# Altium schematic sheet 遥控器_V1_0_D.SchDoc(遥控器_V1_0_D)
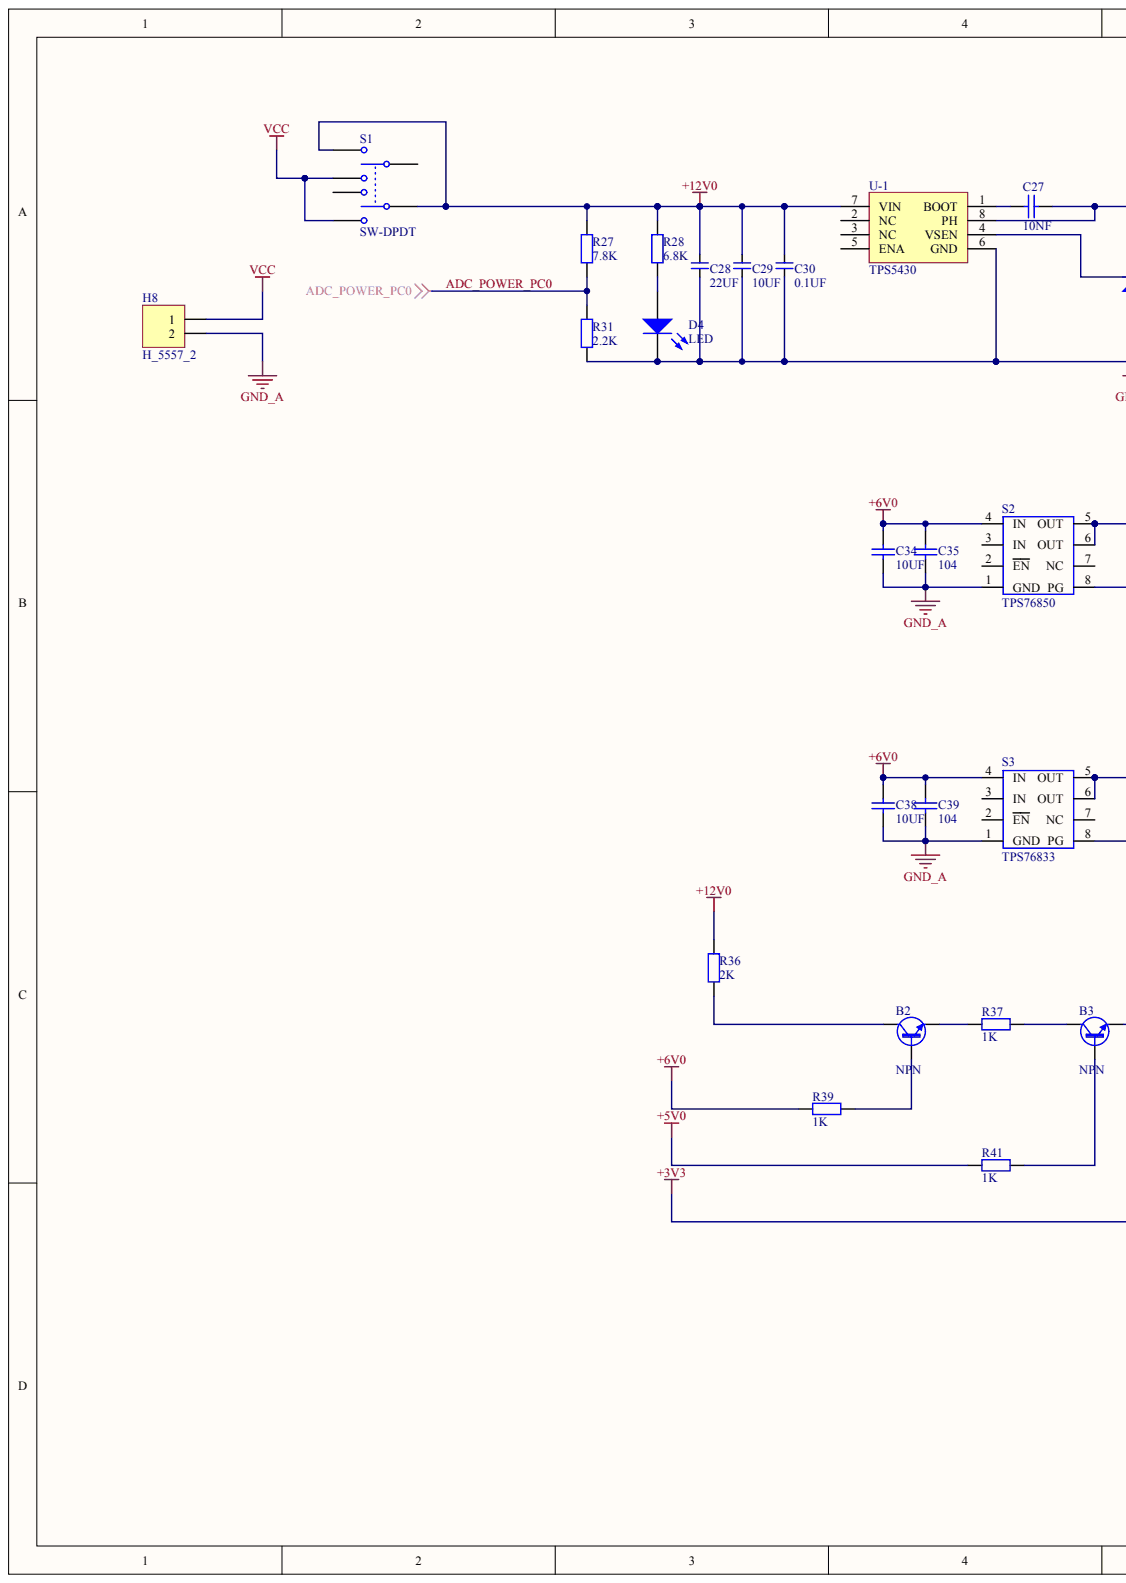
[diagram p0: 遥控器_V1_0_D.SchDoc, left]
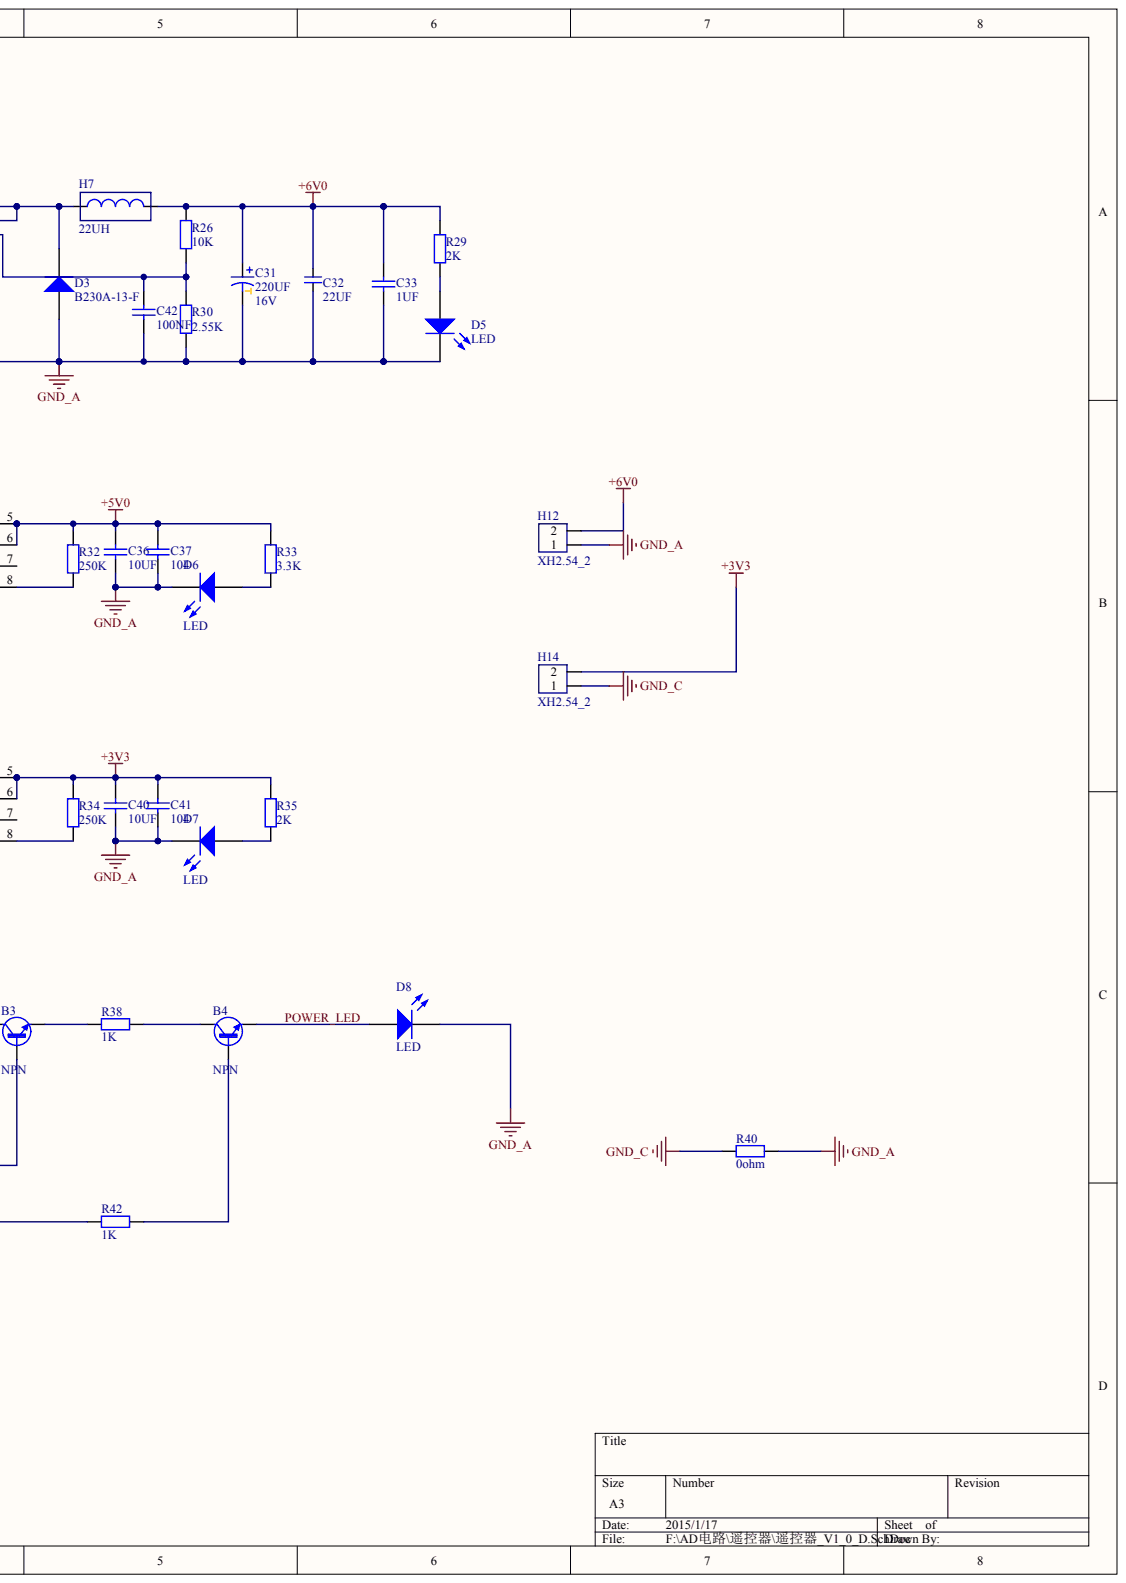
[diagram p1: 遥控器_V1_0_D.SchDoc, right]

## Parts
B2  NPN
B3  NPN
B4  NPN
C27  10NF
C28  22UF
C29  10UF
C30  0.1UF
C31  220UF  V: 16V
C32  22UF
C33  1UF
C34  10UF
C35  104
C36  10UF
C37  104
C38  10UF
C39  104
C40  10UF
C41  104
C42  100NF
D3  B230A-13-F
D4  LED
D5  LED
D6  LED
D7  LED
D8  LED
H7  value 22UH  (hidden comment: inductor(屏蔽))
H8  H_5557_2
H12  XH2.54_2
H14  XH2.54_2
R26  10K
R27  7.8K
R28  6.8K
R29  2K
R30  2.55K
R31  2.2K
R32  250K
R33  3.3K
R34  250K
R35  2K
R36  2K
R37  1K
R38  1K
R39  1K
R40  0ohm
R41  1K
R42  1K
S1  SW-DPDT
S2  TPS76850
S3  TPS76833
U-1  TPS5430

## Nets
+3V3: C40.2 C41.2 H14.2 R34.2 R35.2 R42.1 S3.5(OUT) S3.6(OUT)
+5V0: C36.2 C37.2 R32.2 R33.2 R41.1 S2.5(OUT) S2.6(OUT)
+6V0: C31.1 C32.2 C33.2 C34.2 C35.2 C38.2 C39.2 H7.2 H12.2 R26.2 R29.2 R39.1 S2.4(IN) S3.4(IN)
+12V0: C28.2 C29.2 C30.2 R27.2 R28.2 R36.2 S1.1 S1.6 U-1.7(VIN)
GND_A: C28.1 C29.1 C30.1 C31.2 C32.1 C33.1 C34.1 C35.1 C36.1 C37.1 C38.1 C39.1 C40.1 C41.1 C42.1 D3.1 D4.2 D5.2 D6.2 D7.2 D8.2 H8.2 H12.1 R30.1 R31.1 R40.2 S2.1(GND) S3.1(GND) U-1.6(GND)
GND_C: H14.1 R40.1
VCC: H8.1 S1.2 S1.5
ADC_POWER_PC0: R27.1 R31.2
POWER_LED: B4.2 D8.1
NetB2_1: B2.1 R39.2
NetB2_2: B2.2 R37.1
NetB2_3: B2.3 R36.1
NetB3_1: B3.1 R41.2
NetB3_2: B3.2 R38.1
NetB3_3: B3.3 R37.2
NetB4_1: B4.1 R42.2
NetB4_3: B4.3 R38.2
NetC27_1: C27.1 U-1.1(BOOT)
NetC27_2: C27.2 D3.2 H7.1 U-1.8(PH)
NetC42_2: C42.2 R26.1 R30.2 U-1.4(VSEN)
NetD4_1: D4.1 R28.1
NetD5_1: D5.1 R29.1
NetD6_1: D6.1 R33.1
NetD7_1: D7.1 R35.1
NetR32_1: R32.1 S2.8(PG)
NetR34_1: R34.1 S3.8(PG)

Not connected: S1 3 4; S2 2(EN) 3(IN) 7(NC); S3 2(EN) 3(IN) 7(NC); U-1 2(NC) 3(NC) 5(ENA)

## Library data of the parts
C27 C33-C41 = (no symbol name): value: 100NF
C31 = (no symbol name): Value: 100uF
D3 = (no symbol name): style: 1N4001
R26-R36 R40 = (no symbol name): value: 1K
B2-B4 C28-C30 C32 C42 D4-D8 H7 H8 H12 H14 R37-R39 R41 R42 S1-S3 U-1 = (no symbol name)
  C42: value: 100NF
  H8 H12 H14: LatestRevisionDate: 17-Jul-2002; LatestRevisionNote: Re-released for DXP Platform.
  R37-R39 R41 R42: value: 1K
  S1: Published: 24-Mar-1999; LatestRevisionDate: 17-Jul-2002; LatestRevisionNote: Re-released for DXP Platform.; PackageReference: DPDT-6; PackageDescription: Switch; 6 Leads
  H8 H12 H14 S1: Publisher: Altium Limited
